# Altium schematic sheet PicoInterface.SchDoc
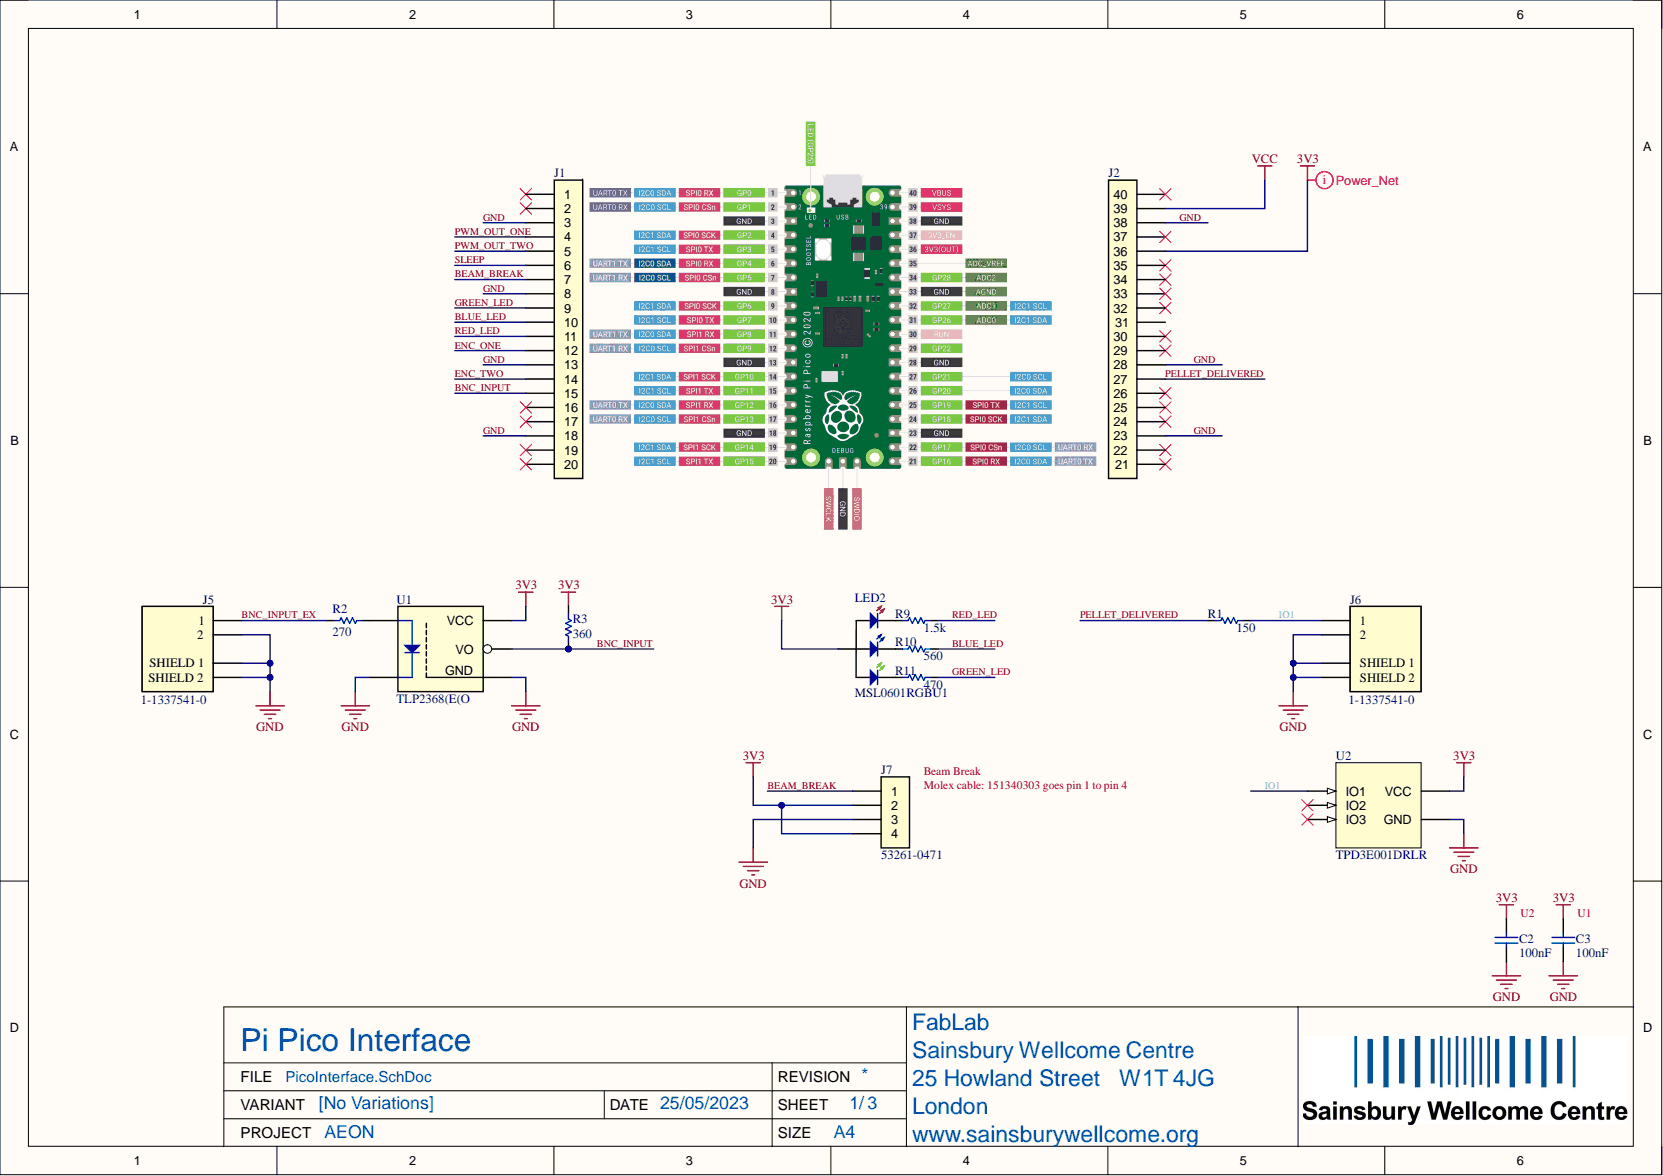
[diagram p0: PicoInterface.SchDoc]

## Parts
C2  value 100nF  Capacitance: 100nF  [FP-GRM188-0_1-e0_2_0_5-IPC_B]  (hidden comment: C0603-100nF-100V-10%-X7R)
C3  value 100nF  Capacitance: 100nF  [FP-GRM188-0_1-e0_2_0_5-IPC_B]  (hidden comment: C0603-100nF-100V-10%-X7R)
J1  SSW-120-01-x-S  [SAMTEC_SSW-120-01-G-S]  (value not drawn)
J2  SSW-120-01-x-S - 21-40  [SAMTEC_SSW-120-01-G-S - 21-40]  (value not drawn)
J5  1-1337541-0  [TE- 1-1337541-0]
J6  1-1337541-0  [TE- 1-1337541-0]
J7  53261-0471  [MOLEX_53261-0471]
LED2  MSL0601RGBU1  [LED_MSL06_ROM]
R1  value 150  [FP-CPF0603-IPC_B]  (hidden comment: R0603-150R-1/16W-0.1%)
R2  value 270  [FP-CPF0603-IPC_B]  (hidden comment: R0603-270R-1/16W-0.1%)
R3  value 360  [FP-CPF0603-IPC_B]  (hidden comment: R0603-360R-1/16W-0.1%)
R9  value 1.5k  Resistance: 1.5k  [FP-CPF0603-IPC_B]  (hidden comment: R0603-1k5-1/16W-0.1%)
R10  value 560  [FP-CPF0603-IPC_B]  (hidden comment: R0603-560R-1/16W-0.1%)
R11  value 470  [FP-CPF0603-IPC_B]  (hidden comment: R0603-470R-1/16W-0.1%)
U1  TLP2368  PartNumber: TLP2368(E(O  [5pin SO6_TOS]
U2  TPD3E001DRLR  [DRL5_TEX]

## Nets
3V3: C2.2 C3.2 J2.36 J7.2 J7.4 LED2.1 R3.2 U1.6(VCC) U2.5(VCC)
VCC: J2.39
~{SLEEP}: J1.6
BEAM_BREAK: J1.7 J7.1
BLUE_LED: J1.10 R10.2
BNC_INPUT: J1.15 R3.1 U1.5(VO)
BNC_INPUT_EX: J5.1 R2.1
ENC_ONE: J1.12
ENC_TWO: J1.14
GND: C2.1 C3.1 J1.3 J1.8 J1.13 J1.18 J2.23 J2.28 J2.38 J5.2 J5.SH1(SHIELD_1) J5.SH2(SHIELD_2) J6.2 J6.SH1(SHIELD_1) J6.SH2(SHIELD_2) J7.3 U1.3 U1.4 U2.3(GND)
GREEN_LED: J1.9 R11.2
IO1: J6.1 R1.2 U2.1(IO1)
PELLET_DELIVERED: J2.27 R1.1
PWM_OUT_ONE: J1.4
PWM_OUT_TWO: J1.5
RED_LED: J1.11 R9.2
NetLED2_2: LED2.2 R11.1
NetLED2_3: LED2.3 R10.1
NetLED2_4: LED2.4 R9.1
NetR2_2: R2.2 U1.1

Not connected: J1 1 2 16 17 19 20; J2 21 22 24 25 26 29 30 31 32 33 34 35 37 40; U2 2(IO2) 4(IO3)

## Library data of the parts
C2 C3 = CMP-611-0027-15: 0.1µF ±10% 100V Ceramic Capacitor X7R 0603 (1608 Metric); Pick&PlaceFootprint: 0603_CAP; Capacitor Type: Ceramic; Case Code (Imperial): 0603; Case Code (Metric): 1608; Case/Package: 0603; Component Kind: Standard; Construction: Flat; Depth: 0.8mm; Dielectric: X7R; Height: 0.8mm; Lead Free: Lead Free; Length: 1.6mm; Material: Ceramic; Max Operating Temperature: 125°C; Min Operating Temperature: -55°C; Mount: Surface Mount; Package Quantity: 1; Packaging: Tape and Reel; Radiation Hardening: No; REACH SVHC: No SVHC; RoHS: Yes; RoHS Compliant: Yes; Series: GRM; Size: 0.8mm; Termination: SMD/SMT; Thickness: 0.035inch; Tolerance: 10%; Voltage: 100V; Voltage Rating: 100V; Voltage Rating (DC): 100V; Weight: 0.000222oz; Width: 0.8mm; Library Name: Sainsbury Wellcome Centre
J1 = CMP-310-0034-2: Conn Socket Strip SKT 20 POS 2.54mm Solder ST Thru-Hole; Gender: Female; Mating Cycles: 100; Number of Contacts: 20; Color: Black; Component Kind: Standard; Contact Material: Bronze; Contact Plating: Gold; Mount: Through Hole; Number of Rows: 1; Pitch: 2.54mm; RoHS Compliant: Yes; Termination: Solder; Library Name: Sainsbury Wellcome Centre
J2 = CMP-310-0035-1: Conn Socket Strip SKT 20 POS 2.54mm Solder ST Thru-Hole (pins 21 - 40); Gender: Female; Mating Cycles: 100; Number of Contacts: 20; Color: Black; Component Kind: Standard; Contact Material: Bronze; Contact Plating: Gold; Mount: Through Hole; Number of Rows: 1; Pitch: 2.54mm; RoHS Compliant: Yes; Termination: Solder; Library Name: Sainsbury Wellcome Centre
J5 J6 = CMP-730-0000-5: TE CONNECTIVITY / GREENPAR         1-1337541-0            RF / Coaxial Connector, BNC Coaxial, Straight Jack, Solder, 50 ohm, Brass; Body Material: Zinc; Body Plating: Nickel; Connector Type: BNC, Jack; Dielectric Material: PE; Frequency: 4GHz; Gender: Female; Impedance: 50R; Insulation Resistance: 5GR; Number of Positions: 1; PCB Mount Retention: With; PCB Mounting Orientation: Vertical; PCB Thickness: 0.126inch; Polarity: Normal; Sealable: No (Without); Tail Length: 5.8mm; Colour: White; Component Kind: Standard; Contact Material: Brass; Contact Plating: Gold; Depth: 15.8mm; ELV: Compliant; Fastening Type: Bayonet; Housing Colour: White; Housing Material: Polyester; Insulation Material: Polybutylene; Length: 14.76mm; Max Frequency: 4GHz; Max Operating Temperature: 85°C; Min Operating Temperature: -55°C; Mount: Panel; Orientation: Straight; Package Quantity: 100; Packaging: Box; PartNumber: 1-1337541-0; Plating: Nickel; Radiation Hardening: No; REACH SVHC: Unknown; Termination: Solder; Library Name: Sainsbury Wellcome Centre
J7 = CMP-370-0017-6: MOLEX 1.25mm (.049") Pitch PicoBlade Header, Surface Mount, Right Angle, Lead-free, 4 Circuits; Contact Resistance: 20mR; Current Rating: 1A; Gender: Male; Glow Wire Compliant: Non-compliant; Insulation Resistance: 100MR; Number of Circuits: 4; Voltage Rating: 125V; Case/Package: 53261-0471; Color: Beige; Component Kind: Standard; Contact Gender: Male; Contact Material: Tin; Contact Plating: Tin; Contact Type: -; Flammability Rating: UL94 V-0; Housing Color: Natural; Housing Material: Thermoplastic; Lead Free: Lead Free; Lead Pitch: 1.25mm; Max Operating Temperature: 105°C; Min Operating Temperature: -40°C; Mount: Surface Mount; Number of Contacts: 4; Number of Positions: 4; Number of Rows: 1; Orientation: Right Angle; Package Quantity: 1000; Packaging: Cut Tape; Pitch: 1.25mm; Radiation Hardening: No; REACH SVHC: Unknown; Series: 53261; Termination: SMD/SMT; Voltage Rating (AC): 125V; Voltage Rating (DC): 125V; Weight: 0.005598oz; Working Voltage: 125V; Library Name: Sainsbury Wellcome Centre
LED2 = CMP-520-0010-2: Triple Color LED, Red/green/blue, Milky White; Pick&PlaceFootprint: MSL06; Component Kind: Standard; Mount: Surface Mount; PartNumber: MSL0601RGBU1; Library Name: Sainsbury Wellcome Centre
R1 = CMP-633-0078-2: Surface Mount Resistor 150 Ohm 1/16 W 0.1% 0603 (1608 Metric); Max Power Dissipation: 62.5 mW; Number of Resistors: 1; Pick&PlaceFootprint: R0603; Power Rating: 62.5mW; Temperature Coefficient: 25ppm/°C; Voltage Rating: 50V; Case Code (Imperial): 0603; Case Code (Metric): 1608; Case/Package: 0603; Component Kind: Standard; Composition: Thin Film; Construction: Chip; ELV: Compliant; Height: 0.45mm; Length: 1.6mm; Max Operating Temperature: 155°C; Min Operating Temperature: -55°C; Mount: Surface Mount; Number of Pins: 2; Number of Terminations: 2; Packaging: Tape and Reel; REACH SVHC: Yes; Resistance: 150; Resistance Tolerance: 0.1%; Resistor Type: FIXED RESISTOR; RoHS: Yes; RoHS Compliant: Yes; Series: CPF; Size Code: 0603; Technology: THIN FILM; Termination: Wraparound; Tolerance: 0.1%; Voltage Rating (DC): 50V; Weight: 7.1E-05oz; Width: 0.8mm; Library Name: Sainsbury Wellcome Centre
R2 = CMP-633-0083-1: Surface Mount Resistor 270 Ohm 1/16 W 0.1% 0603 (1608 Metric); Max Power Dissipation: 62.5 mW; Number of Resistors: 1; Pick&PlaceFootprint: R0603; Power Rating: 62.5mW; Temperature Coefficient: 25ppm/°C; Voltage Rating: 50V; Case Code (Imperial): 0603; Case Code (Metric): 1608; Case/Package: 0603; Component Kind: Standard; Composition: Thin Film; Construction: Chip; ELV: Compliant; Height: 0.45mm; Length: 1.6mm; Max Operating Temperature: 155°C; Min Operating Temperature: -55°C; Mount: Surface Mount; Number of Pins: 2; Number of Terminations: 2; Packaging: Tape and Reel; REACH SVHC: Yes; Resistance: 270; Resistance Tolerance: 0.1%; Resistor Type: FIXED RESISTOR; RoHS: Yes; RoHS Compliant: Yes; Series: CPF; Size Code: 0603; Technology: THIN FILM; Termination: Wraparound; Tolerance: 0.1%; Voltage Rating (DC): 50V; Weight: 7.1E-05oz; Width: 0.8mm; Library Name: Sainsbury Wellcome Centre
R3 = CMP-633-0019-16: Surface Mount Resistor 360 Ohm 1/16 W 0.1% 0603 (1608 Metric); Max Power Dissipation: 62.5 mW; Number of Resistors: 1; Pick&PlaceFootprint: R0603; Power Rating: 62.5mW; Temperature Coefficient: 25ppm/°C; Voltage Rating: 50V; Case Code (Imperial): 0603; Case Code (Metric): 1608; Case/Package: 0603; Component Kind: Standard; Composition: Thin Film; ELV: Compliant; Height: 0.45mm; Length: 1.6mm; Max Operating Temperature: 155°C; Min Operating Temperature: -55°C; Mount: Surface Mount; Number of Pins: 2; Number of Terminations: 2; Packaging: Tape and Reel; REACH SVHC: No SVHC; Resistance: 360; Resistance Tolerance: 0.1%; Resistor Type: Thin Film; RoHS: Yes; RoHS Compliant: Yes; Series: CPF; Size Code: 0603; Technology: Thin Film; Termination: Solder; Tolerance: 0.1%; Voltage Rating (DC): 50V; Weight: 7.1E-05oz; Width: 0.8mm; Library Name: Sainsbury Wellcome Centre
R9 = CMP-633-0029-14: Surface Mount Resistor 1k5 Ohm 1/16 W 0.1% 0603 (1608 Metric); Max Power Dissipation: 62.5 mW; Number of Resistors: 1; Pick&PlaceFootprint: R0603; Power Rating: 62.5mW; Temperature Coefficient: 25ppm/°C; Voltage Rating: 50V; Case Code (Imperial): 0603; Case Code (Metric): 1608; Case/Package: 0603; Component Kind: Standard; Composition: Thin Film; ELV: Compliant; Height: 0.45mm; Length: 1.6mm; Max Operating Temperature: 155°C; Min Operating Temperature: -55°C; Mount: Surface Mount; Number of Pins: 2; Number of Terminations: 2; Packaging: Tape and Reel; REACH SVHC: No SVHC; Resistance Tolerance: 0.1%; Resistor Type: Thin Film; RoHS: Yes; RoHS Compliant: Yes; Series: CPF; Size Code: 0603; Technology: Thin Film; Termination: Solder; Tolerance: 0.1%; Voltage Rating (DC): 50V; Weight: 7.1E-05oz; Width: 0.8mm; Library Name: Sainsbury Wellcome Centre
R10 = CMP-633-0030-9: Surface Mount Resistor 560 Ohm 1/16 W 0.1% 0603 (1608 Metric); Max Power Dissipation: 62.5 mW; Number of Resistors: 1; Pick&PlaceFootprint: R0603; Power Rating: 62.5mW; Temperature Coefficient: 25ppm/°C; Voltage Rating: 50V; Case Code (Imperial): 0603; Case Code (Metric): 1608; Case/Package: 0603; Component Kind: Standard; Composition: Thin Film; ELV: Compliant; Height: 0.45mm; Length: 1.6mm; Max Operating Temperature: 155°C; Min Operating Temperature: -55°C; Mount: Surface Mount; Number of Pins: 2; Number of Terminations: 2; Packaging: Tape and Reel; REACH SVHC: No SVHC; Resistance: 560; Resistance Tolerance: 0.1%; Resistor Type: Thin Film; RoHS: Yes; RoHS Compliant: Yes; Series: CPF; Size Code: 0603; Technology: Thin Film; Termination: Solder; Tolerance: 0.1%; Voltage Rating (DC): 50V; Weight: 7.1E-05oz; Width: 0.8mm; Library Name: Sainsbury Wellcome Centre
R11 = CMP-633-0020-13: Surface Mount Resistor 470 Ohm 1/16 W 0.1% 0603 (1608 Metric); Max Power Dissipation: 62.5 mW; Number of Resistors: 1; Pick&PlaceFootprint: R0603; Power Rating: 62.5mW; Temperature Coefficient: 25ppm/°C; Voltage Rating: 50V; Case Code (Imperial): 0603; Case Code (Metric): 1608; Case/Package: 0603; Component Kind: Standard; Composition: Thin Film; ELV: Compliant; Height: 0.45mm; Length: 1.6mm; Max Operating Temperature: 155°C; Min Operating Temperature: -55°C; Mount: Surface Mount; Number of Pins: 2; Number of Terminations: 2; Packaging: Tape and Reel; REACH SVHC: No SVHC; Resistance: 470; Resistance Tolerance: 0.1%; Resistor Type: Thin Film; RoHS: Yes; RoHS Compliant: Yes; Series: CPF; Size Code: 0603; Technology: Thin Film; Termination: Solder; Tolerance: 0.1%; Voltage Rating (DC): 50V; Weight: 7.1E-05oz; Width: 0.8mm; Library Name: Sainsbury Wellcome Centre
U1 = CMP-530-0000-11: TOSHIBA         TLP2368(E(O             Digital Output Optocoupler, Open Collector, 1 Channel, SOIC, 5 Pins, 3.75 kV, 20 Mbaud; Direction: Unidirectional; Forward Current: 25mA; Forward Voltage: 1.7V; Input Current: 14mA; Isolation Voltage: 3.75kV; Number of Channels: 1; Pick&PlaceFootprint: SO6; Power Dissipation: 60mW; Propagation Delay: 60ns; Reverse Breakdown Voltage: 5V; Case/Package: SO; Component Kind: Standard; Max Operating Temperature: 125°C; Min Operating Temperature: -40°C; Mount: Surface Mount; Number of Elements: 1; Number of Pins: 5; Output Current: 25mA; Packaging: Tape and Reel; Radiation Hardening: No; REACH SVHC: No SVHC; Library Name: Sainsbury Wellcome Centre
U2 = CMP-820-0000-4: Low-Capacitance 3-Channel +/-15KV ESD-Protection Array for High-Speed Data Interfaces 5-SOT-5X3; Pick&PlaceFootprint: DRL-5; Component Kind: Standard; Mount: Surface Mount; PartNumber: TPD3E001DRLR; Library Name: Sainsbury Wellcome Centre
